# Altium schematic sheet io_interface.SchDoc(io_interface)
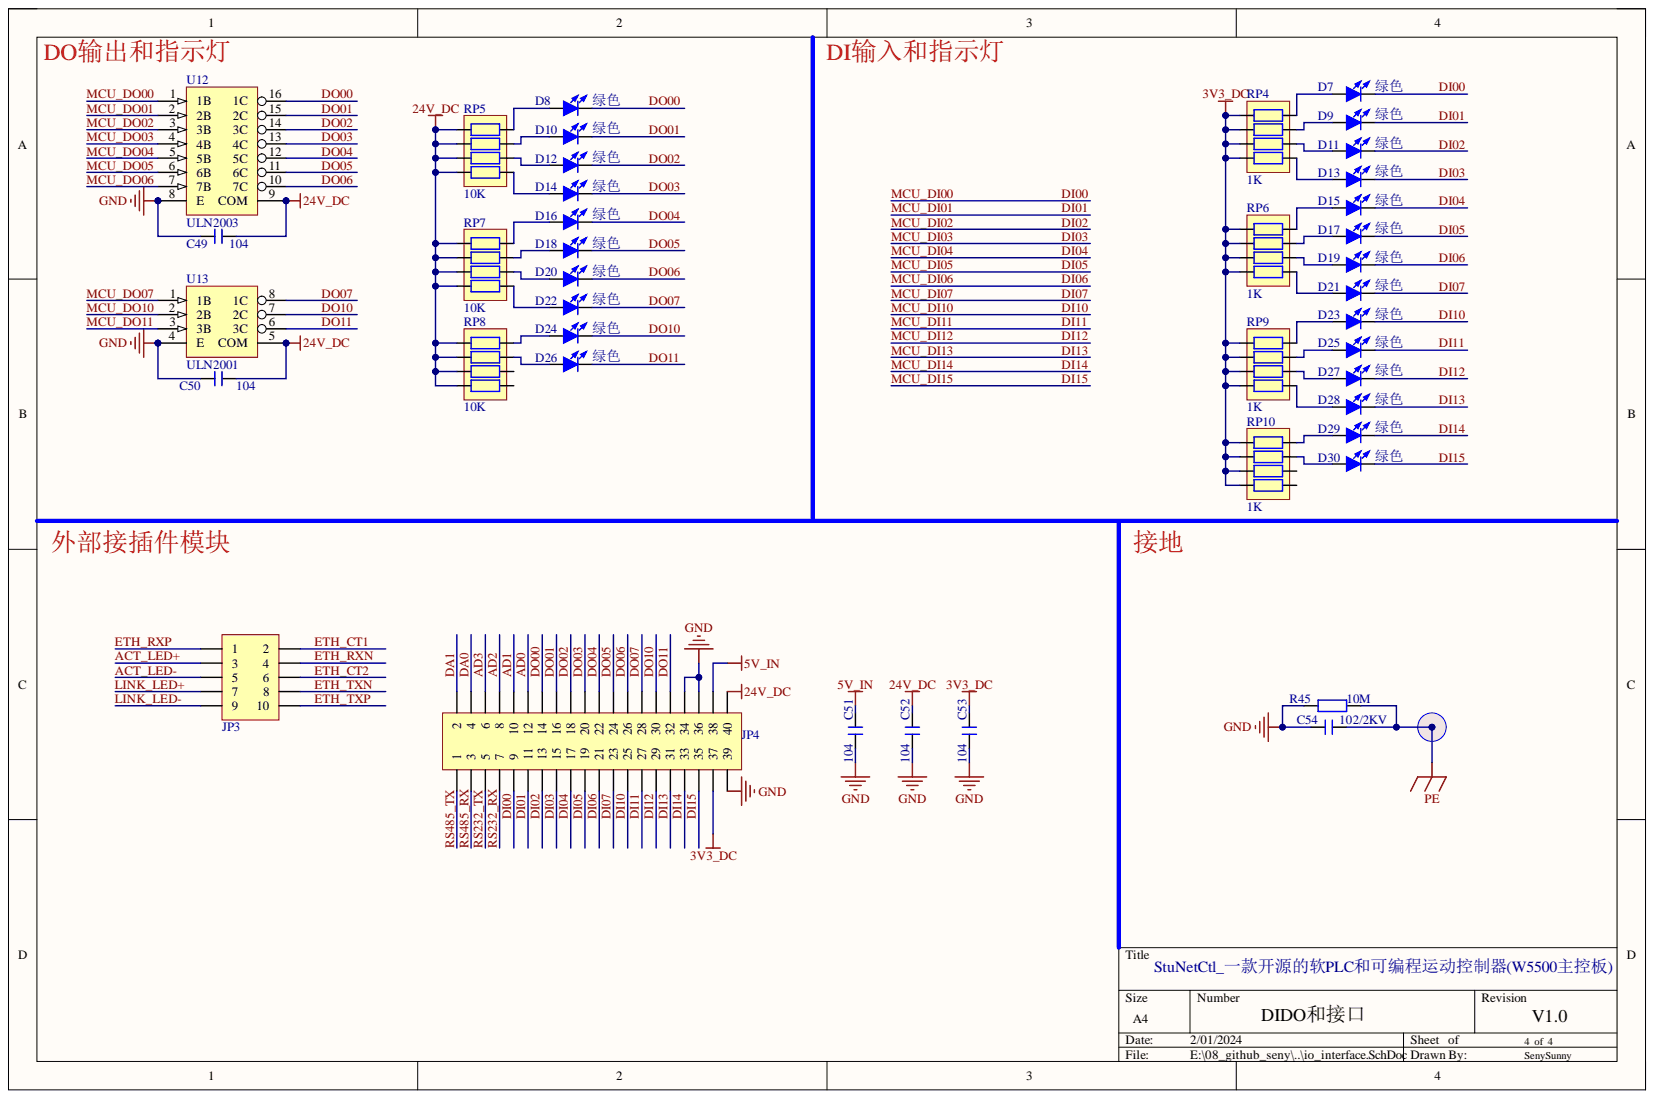
[diagram p0: io_interface.SchDoc]

## Parts
C49  104  [C 0603]
C50  104  [C 0603]
C51  104  [C 0603]
C52  104  [C 0603]
C53  104  [C 0603]
C54  102/2KV  [C 1206]
D7  绿色  [LED 0805G]
D8  绿色  [LED 0805G]
D9  绿色  [LED 0805G]
D10  绿色  [LED 0805G]
D11  绿色  [LED 0805G]
D12  绿色  [LED 0805G]
D13  绿色  [LED 0805G]
D14  绿色  [LED 0805G]
D15  绿色  [LED 0805G]
D16  绿色  [LED 0805G]
D17  绿色  [LED 0805G]
D18  绿色  [LED 0805G]
D19  绿色  [LED 0805G]
D20  绿色  [LED 0805G]
D21  绿色  [LED 0805G]
D22  绿色  [LED 0805G]
D23  绿色  [LED 0805G]
D24  绿色  [LED 0805G]
D25  绿色  [LED 0805G]
D26  绿色  [LED 0805G]
D27  绿色  [LED 0805G]
D28  绿色  [LED 0805G]
D29  绿色  [LED 0805G]
D30  绿色  [LED 0805G]
JP3  Header 5X2  [HDR2.54 2x5-M-SMD]  (value not drawn)
JP4  Header 2X11  [HDR2.54 2x20-M-SMD]  (value not drawn)
R45  10M  [R 1206]
RP4  1K  [RCA-8P4R-0603]
RP5  10K  [RCA-8P4R-0603]
RP6  1K  [RCA-8P4R-0603]
RP7  10K  [RCA-8P4R-0603]
RP8  10K  [RCA-8P4R-0603]
RP9  1K  [RCA-8P4R-0603]
RP10  1K  [RCA-8P4R-0603]
T1  测试点  [Test_1P_PE]  (value not drawn)
U12  ULN2003  [SOP16_L]
U13  ULN2001  [SOP8_L]

## Nets
3V3_DC: C53.1 JP4.37 RP4.1 RP4.2 RP4.3 RP4.4 RP6.1 RP6.2 RP6.3 RP6.4 RP9.1 RP9.2 RP9.3 RP9.4 RP10.1 RP10.2 RP10.3 RP10.4
5V_IN: C51.1 JP4.38
24V_DC: C49.1 C50.1 C52.1 JP4.40 RP5.5 RP5.6 RP5.7 RP5.8 RP7.5 RP7.6 RP7.7 RP7.8 RP8.1 RP8.2 RP8.3 RP8.4 U12.9(COM) U13.5(COM)
GND: C49.2 C50.2 C51.2 C52.2 C53.2 C54.2 JP4.34 JP4.36 JP4.39 R45.2 U12.8(E) U13.4(E)
PE: C54.1 R45.1 T1.0
ACT_LED+: JP3.3
ACT_LED-: JP3.5
AD0: JP4.12
AD1: JP4.10
AD2: JP4.8
AD3: JP4.6
DA0: JP4.4
DA1: JP4.2
DI00: D7.2 JP4.9
DI01: D9.2 JP4.11
DI02: D11.2 JP4.13
DI03: D13.2 JP4.15
DI04: D15.2 JP4.17
DI05: D17.2 JP4.19
DI06: D19.2 JP4.21
DI07: D21.2 JP4.23
DI10: D23.2 JP4.25
DI11: D25.2 JP4.27
DI12: D27.2 JP4.29
DI13: D28.2 JP4.31
DI14: D29.2 JP4.33
DI15: D30.2 JP4.35
DO00: D8.2 JP4.14 U12.16(1C)
DO01: D10.2 JP4.16 U12.15(2C)
DO02: D12.2 JP4.18 U12.14(3C)
DO03: D14.2 JP4.20 U12.13(4C)
DO04: D16.2 JP4.22 U12.12(5C)
DO05: D18.2 JP4.24 U12.11(6C)
DO06: D20.2 JP4.26 U12.10(7C)
DO07: D22.2 JP4.28 U13.8(1C)
DO10: D24.2 JP4.30 U13.7(2C)
DO11: D26.2 JP4.32 U13.6(3C)
ETH_CT1: JP3.2
ETH_CT2: JP3.6
ETH_RXN: JP3.4
ETH_RXP: JP3.1
ETH_TXN: JP3.8
ETH_TXP: JP3.10
LINK_LED+: JP3.7
LINK_LED-: JP3.9
MCU_DO00: U12.1(1B)
MCU_DO01: U12.2(2B)
MCU_DO02: U12.3(3B)
MCU_DO03: U12.4(4B)
MCU_DO04: U12.5(5B)
MCU_DO05: U12.6(6B)
MCU_DO06: U12.7(7B)
MCU_DO07: U13.1(1B)
MCU_DO10: U13.2(2B)
MCU_DO11: U13.3(3B)
RS232_RX: JP4.7
RS232_TX: JP4.5
RS485_RX: JP4.3
RS485_TX: JP4.1
NetD7_1: D7.1 RP4.8
NetD8_1: D8.1 RP5.1
NetD9_1: D9.1 RP4.7
NetD10_1: D10.1 RP5.2
NetD11_1: D11.1 RP4.6
NetD12_1: D12.1 RP5.3
NetD13_1: D13.1 RP4.5
NetD14_1: D14.1 RP5.4
NetD15_1: D15.1 RP6.8
NetD16_1: D16.1 RP7.1
NetD17_1: D17.1 RP6.7
NetD18_1: D18.1 RP7.2
NetD19_1: D19.1 RP6.6
NetD20_1: D20.1 RP7.3
NetD21_1: D21.1 RP6.5
NetD22_1: D22.1 RP7.4
NetD23_1: D23.1 RP9.8
NetD24_1: D24.1 RP8.5
NetD25_1: D25.1 RP9.7
NetD26_1: D26.1 RP8.6
NetD27_1: D27.1 RP9.6
NetD28_1: D28.1 RP9.5
NetD29_1: D29.1 RP10.8
NetD30_1: D30.1 RP10.7

Not connected: RP8 7 8; RP10 5 6

## Library data of the parts
C49-C54 = C: 无极性贴片电容; 版权归属: 源创客; BOM名称: 无极性贴片电容; Component Kind: Standard; 学习资源: www.ooocke.com; Library Name: zblib_v1.IntLib
D7-D30 = LED-SMD: 贴片LED; 版权归属: 源创客; BOM名称: 贴片LED; Component Kind: Standard; 学习资源: www.ooocke.com; Library Name: zblib_v1.IntLib
JP3 = Header 5X2: 5*2P接插件; 版权归属: 源创客; BOM名称: 5*2P接插件; Component Kind: Standard; 学习资源: www.ooocke.com; Library Name: zblib_v1.IntLib
JP4 = Header 20X2: 20*2P接插件; 版权归属: 源创客; BOM名称: 20*2P接插件; Component Kind: Standard; 学习资源: www.ooocke.com; Library Name: zblib_v1.IntLib
R45 = R: 贴片电阻; 版权归属: 源创客; BOM名称: 贴片电阻; Component Kind: Standard; 学习资源: www.ooocke.com; Library Name: zblib_v1.IntLib
RP4-RP10 = R-8P4R: 贴片网络排阻; 版权归属: 源创客; BOM名称: 贴片网络排阻; Component Kind: Standard; 学习资源: www.ooocke.com; Library Name: zblib_v1.IntLib
T1 = TEST_PT: Component Kind: Standard; Library Name: zblib_v1.IntLib
U12 = ULN2003-2: 达林顿驱动芯片; 版权归属: 源创客; BOM名称: 达林顿驱动芯片; Component Kind: Standard; 学习资源: www.ooocke.com; Library Name: zblib_v1.IntLib
U13 = ULN2001: 达林顿驱动芯片; 版权归属: 源创客; BOM名称: 达林顿驱动芯片; Component Kind: Standard; 学习资源: www.ooocke.com; Library Name: zblib_v1.IntLib
